# Altium schematic sheet [3] TopLevel.SchDoc("[3] TopLevel")
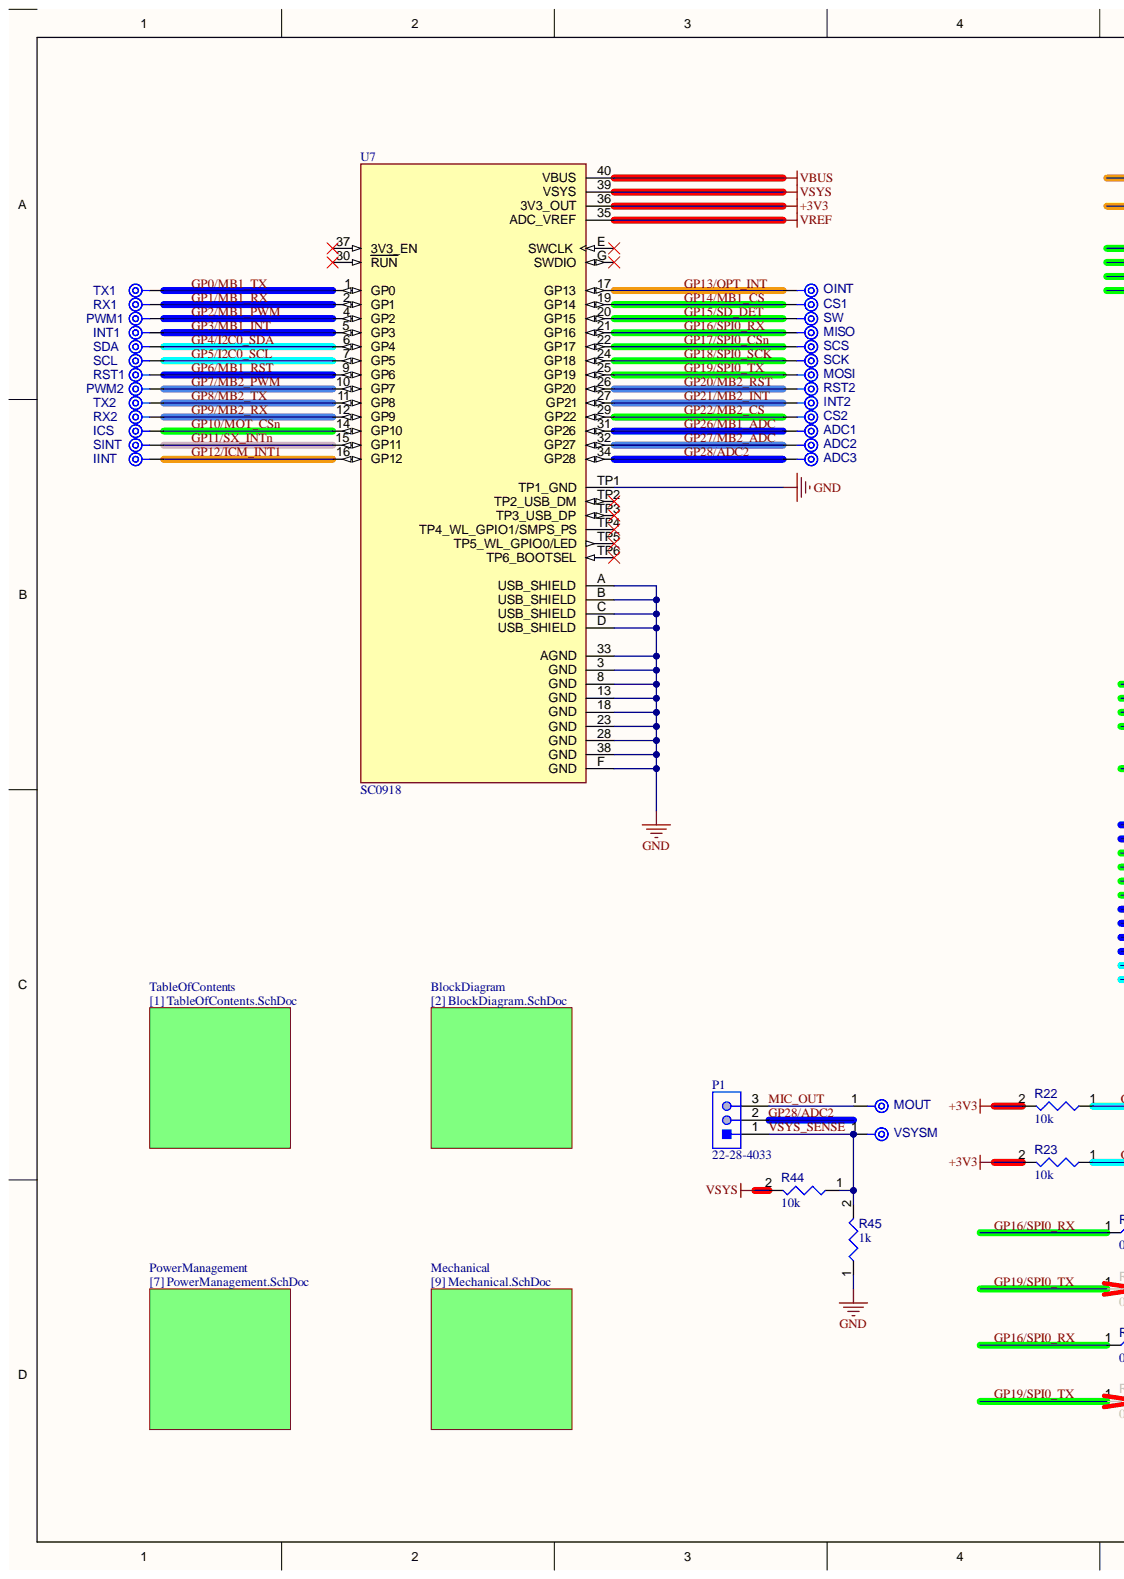
[diagram p0: [3] TopLevel.SchDoc, left]
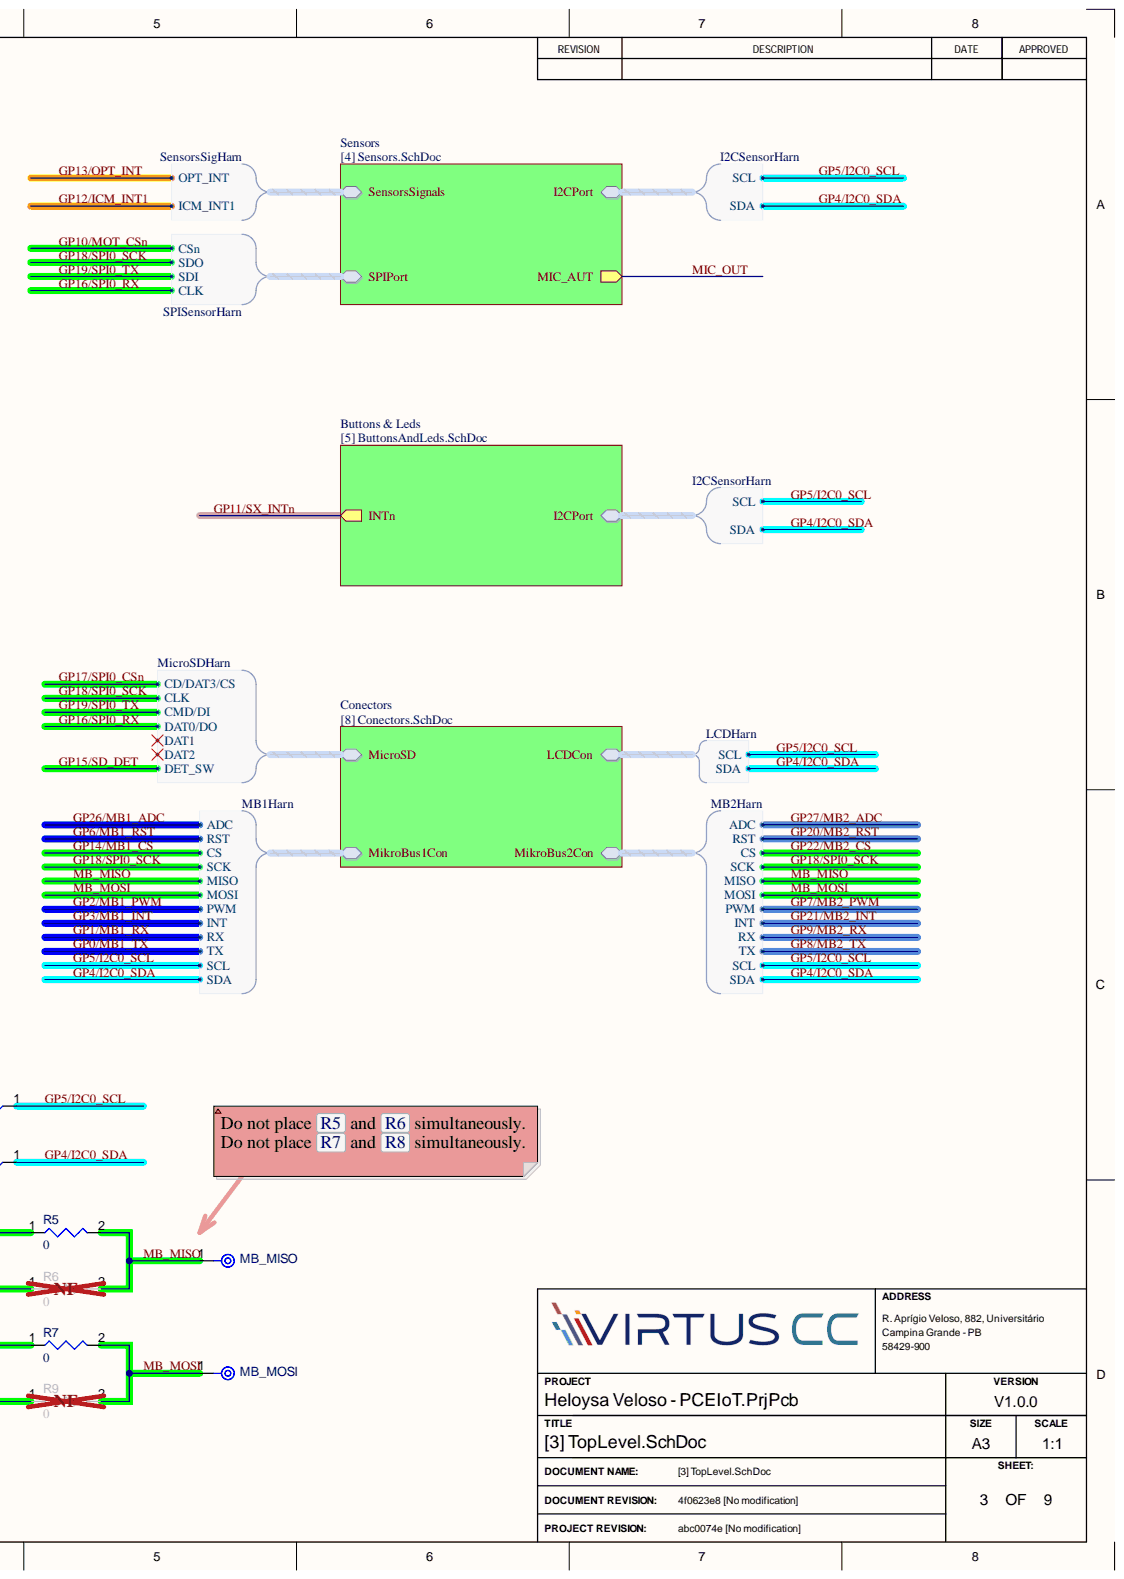
[diagram p1: [3] TopLevel.SchDoc, right]

## Parts
ADC1  TPAD6R  [TP06R]  (value not drawn)  (no BOM)
ADC2  TPAD6R  [TP06R]  (value not drawn)  (no BOM)
ADC3  TPAD6R  [TP06R]  (value not drawn)  (no BOM)
CS1  TPAD6R  [TP06R]  (value not drawn)  (no BOM)
CS2  TPAD6R  [TP06R]  (value not drawn)  (no BOM)
ICS  TPAD6R  [TP06R]  (value not drawn)  (no BOM)
IINT  TPAD6R  [TP06R]  (value not drawn)  (no BOM)
INT1  TPAD6R  [TP06R]  (value not drawn)  (no BOM)
INT2  TPAD6R  [TP06R]  (value not drawn)  (no BOM)
MB_MISO  TPAD6R  [TP06R]  (value not drawn)  (no BOM)
MB_MOSI  TPAD6R  [TP06R]  (value not drawn)  (no BOM)
MISO  TPAD6R  [TP06R]  (value not drawn)  (no BOM)
MOSI  TPAD6R  [TP06R]  (value not drawn)  (no BOM)
MOUT  TPAD6R  [TP06R]  (value not drawn)  (no BOM)
OINT  TPAD6R  [TP06R]  (value not drawn)  (no BOM)
P1  22-28-4033  [MOLX-22-28-4033_V]
PWM1  TPAD6R  [TP06R]  (value not drawn)  (no BOM)
PWM2  TPAD6R  [TP06R]  (value not drawn)  (no BOM)
R5  value 0  [RESC0603(1608)_L]  (hidden comment: Resistor 0R +/- 5% 0603 100 mW)
R6  value 0  [RESC0603(1608)_L]  (hidden comment: Resistor 0R +/- 5% 0603 100 mW)
R7  value 0  [RESC0603(1608)_L]  (hidden comment: Resistor 0R +/- 5% 0603 100 mW)
R9  value 0  [RESC0603(1608)_L]  (hidden comment: Resistor 0R +/- 5% 0603 100 mW)
R22  value 10k  [RESC0603(1608)_L]  (hidden comment: Resistor 10k +/-1% 0603 100 mW)
R23  value 10k  [RESC0603(1608)_L]  (hidden comment: Resistor 10k +/-1% 0603 100 mW)
R44  value 10k  [RESC0603(1608)_L]  (hidden comment: Resistor 10k +/-1% 0603 100 mW)
R45  value 1k  [RESC0603(1608)_L]  (hidden comment: Resistor 1k +/-1% 0603 100 mW)
RST1  TPAD6R  [TP06R]  (value not drawn)  (no BOM)
RST2  TPAD6R  [TP06R]  (value not drawn)  (no BOM)
RX1  TPAD6R  [TP06R]  (value not drawn)  (no BOM)
RX2  TPAD6R  [TP06R]  (value not drawn)  (no BOM)
SCK  TPAD6R  [TP06R]  (value not drawn)  (no BOM)
SCL  TPAD6R  [TP06R]  (value not drawn)  (no BOM)
SCS  TPAD6R  [TP06R]  (value not drawn)  (no BOM)
SDA  TPAD6R  [TP06R]  (value not drawn)  (no BOM)
SINT  TPAD6R  [TP06R]  (value not drawn)  (no BOM)
SW  TPAD6R  [TP06R]  (value not drawn)  (no BOM)
TX1  TPAD6R  [TP06R]  (value not drawn)  (no BOM)
TX2  TPAD6R  [TP06R]  (value not drawn)  (no BOM)
U7  SC0918  [MODULE_SC0918]
VSYSM  TPAD6R  [TP06R]  (value not drawn)  (no BOM)

## Nets
+3V3: R22.2 R23.2 U7.36(3V3_OUT)
GND: R45.1 U7.3(GND) U7.8(GND) U7.13(GND) U7.18(GND) U7.23(GND) U7.28(GND) U7.33(AGND) U7.38(GND) U7.A(USB_SHIELD) U7.B(USB_SHIELD) U7.C(USB_SHIELD) U7.D(USB_SHIELD) U7.F(GND) U7.TP1(TP1_GND)
VBUS: U7.40(VBUS)
VREF: U7.35(ADC_VREF)
VSYS: R44.2 U7.39(VSYS)
GP0/MB1_TX: TX1.1 U7.1(GP0)
GP1/MB1_RX: RX1.1 U7.2(GP1)
GP2/MB1_PWM: PWM1.1 U7.4(GP2)
GP3/MB1_INT: INT1.1 U7.5(GP3)
GP4/I2C0_SDA: R23.1 SDA.1 U7.6(GP4)
GP5/I2C0_SCL: R22.1 SCL.1 U7.7(GP5)
GP6/MB1_RST: RST1.1 U7.9(GP6)
GP7/MB2_PWM: PWM2.1 U7.10(GP7)
GP8/MB2_TX: TX2.1 U7.11(GP8)
GP9/MB2_RX: RX2.1 U7.12(GP9)
GP10/MOT_CSn: ICS.1 U7.14(GP10)
GP11/SX_INTn: SINT.1 U7.15(GP11)
GP12/ICM_INT1: IINT.1 U7.16(GP12)
GP13/OPT_INT: OINT.1 U7.17(GP13)
GP14/MB1_CS: CS1.1 U7.19(GP14)
GP15/SD_DET: SW.1 U7.20(GP15)
GP16/SPI0_RX: MISO.1 R5.1 R7.1 U7.21(GP16)
GP17/SPI0_CSn: SCS.1 U7.22(GP17)
GP18/SPI0_SCK: SCK.1 U7.24(GP18)
GP19/SPI0_TX: MOSI.1 R6.1 R9.1 U7.25(GP19)
GP20/MB2_RST: RST2.1 U7.26(GP20)
GP21/MB2_INT: INT2.1 U7.27(GP21)
GP22/MB2_CS: CS2.1 U7.29(GP22)
GP26/MB1_ADC: ADC1.1 U7.31(GP26)
GP27/MB2_ADC: ADC2.1 U7.32(GP27)
GP28/ADC2: ADC3.1 P1.2 U7.34(GP28)
MB_MISO: MB_MISO.1 R5.2 R6.2
MB_MOSI: MB_MOSI.1 R7.2 R9.2
MIC_OUT: MOUT.1 P1.3
VSYS_SENSE: P1.1 R44.1 R45.2 VSYSM.1
NetU7_30: U7.30(RUN)
NetU7_37: U7.37(3V3_EN)
NetU7_E: U7.E(SWCLK)
NetU7_G: U7.G(SWDIO)
NetU7_TP2: U7.TP2(TP2_USB_DM)
NetU7_TP3: U7.TP3(TP3_USB_DP)
NetU7_TP4: U7.TP4(TP4_WL_GPIO1/SMPS_PS)
NetU7_TP5: U7.TP5(TP5_WL_GPIO0/LED)
NetU7_TP6: U7.TP6(TP6_BOOTSEL)
MicroSD.DAT1:
MicroSD.DAT2:

## Library data of the parts
ADC1-ADC3 CS1 CS2 ICS IINT INT1 INT2 MB_MISO MB_MOSI MISO MOSI MOUT OINT PWM1 PWM2 RST1 RST2 RX1 RX2 SCK SCL SCS SDA SINT SW TX1 TX2 VSYSM = CMP-00028-00002-2: 0.6mm round; Pins: 1; Library Name: UFCG - Universidade Federal de Campina Grande
P1 = CMP-002-00076-1: KK 254 Breakaway Header Vertical 3 Circuits 0.38um Gold Selective Plating Mating Pin 6.09mm; Contact Resistance: 20mR; Current Rating: 4A; Gender: Male; Glow Wire Compliant: Non-compliant; Max Voltage Rating (AC): 250V; Number of Circuits: 3; Pitch: 2.54mm; Color: Black; Component Kind: Standard; Contact Material: Brass,Bronze; Contact Mating Length: 0.24inch; Contact Plating: Gold; Contact Type: Male Pin; Depth: 2.49mm; Flammability Rating: UL94 V-0; Housing Color: Black; Housing Material: Nylon; Lead Free: Lead Free; Max Operating Temperature: 75°C; Max Voltage Rating (DC): 250V; Min Operating Temperature: 0°C; Mounting Technology: Through Hole; Number of Contacts: 3; Number of Positions: 3; Number of Rows: 1; Orientation: Straight; Package Quantity: 1; Packaging: Bulk; Radiation Hardening: No; Reach SVHC Compliant: Unknown; RoHS Compliant: Yes; Series: KK® 42375; Termination: Solder; Voltage Rating: 250V; Voltage Rating (DC): 250V; Library Name: UFCG - Universidade Federal de Campina Grande
R5-R7 R9 = CMP-009-00006-6: Chip Resistor, 0 Ohm, 0.1 W, -55 to 155 degC, 0603 (1608 Metric); Power: 100mW; Voltage Rating: 50V; Case/Package: 0603; Component Kind: Standard; Max Operating Temperature: 155°C; Min Operating Temperature: -55°C; Mounting Technology: SM; Pins: 2; RoHS Compliant: True; Tolerance: 5%; Library Name: UFCG - Universidade Federal de Campina Grande
R22 R23 R44 = CMP-009-00017-7: Chip Resistor, 10 KOhm, +/- 1%, 01 W, -55 to 155 degC, 0603 (1608 Metric); Power: 100mW; Voltage Rating: 50V; Case/Package: 0603; Component Kind: Standard; Max Operating Temperature: 155°C; Min Operating Temperature: -55°C; Mounting Technology: SM; Pins: 2; RoHS Compliant: True; Tolerance: 1%; Library Name: UFCG - Universidade Federal de Campina Grande
R45 = CMP-009-00014-6: Chip Resistor, 1 KOhm, +/-1%, 0.1 W, -55 to 155 degC, 0603 (1608 Metric); Power: 100mW; Tolerance: 1%; Voltage Rating: 50V; Case/Package: 0603; Component Kind: Standard; Max Operating Temperature: 155°C; Min Operating Temperature: -55°C; Mounting Technology: SM; Pins: 2; RoHS Compliant: True; Library Name: UFCG - Universidade Federal de Campina Grande
U7 = CMP-006-000001-6: Raspberry Pi Pico W RP2040; Availability: In Stock; Check_prices: https://www.snapeda.com/parts/SC0918/Raspberry+Pi/view-part/?ref=eda; Component Kind: Standard; Component Type: Standard; Design Item ID: SC0918; MF: Raspberry Pi; MP: SC0918; Package: None; Pin Count: 53; Price: None; Purchase-URL: https://pricing.snapeda.com/search/part/SC0918/?ref=eda; SnapEDA_Link: https://www.snapeda.com/parts/SC0918/Raspberry+Pi/view-part/?ref=snap; Source: SC0918.SchLib; Library Name: UFCG - Universidade Federal de Campina Grande
